# Altium schematic sheet Power.SchDoc(Power)
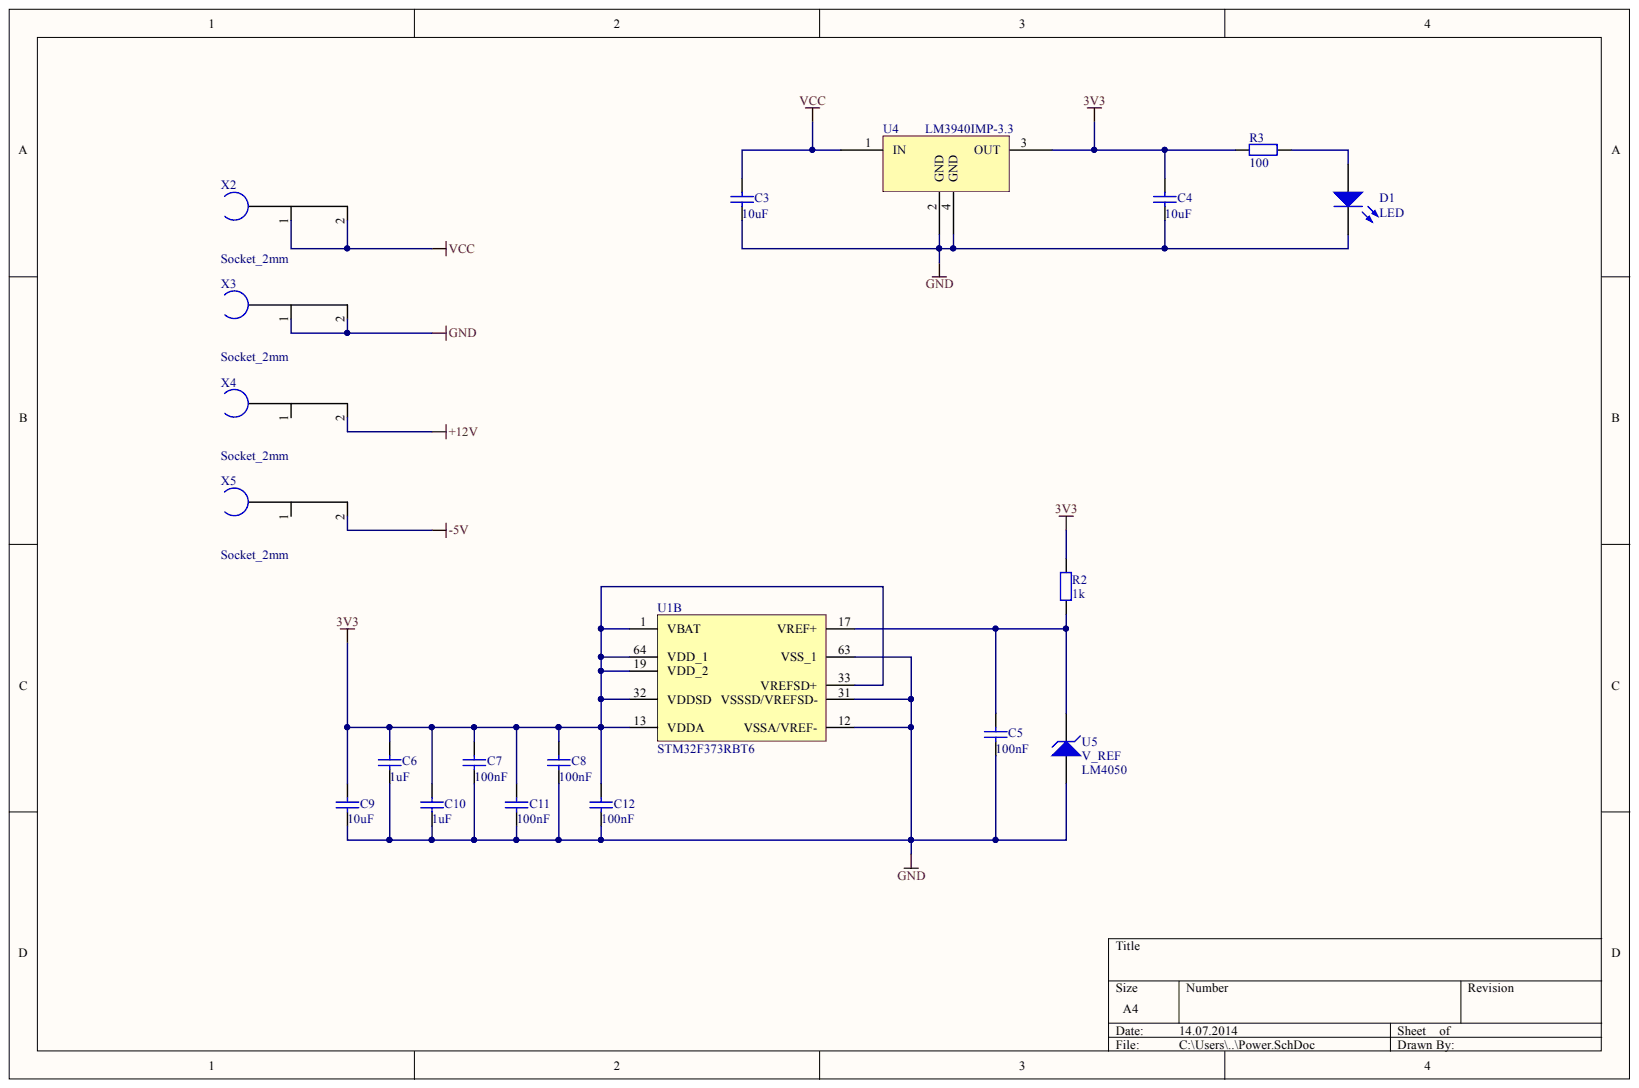
[diagram p0: Power.SchDoc]

## Parts
C3  C  value 10uF  [0805]
C4  C  value 10uF  [0805]
C5  C  value 100nF  [0805]
C6  C  value 1uF  [0805]
C7  C  value 100nF  [0805]
C8  C  value 100nF  [0805]
C9  C  value 10uF  [0805]
C10  C  value 1uF  [0805]
C11  C  value 100nF  [0805]
C12  C  value 100nF  [0805]
D1  LED  [D_0603]
R2  R  value 1k  [R_0603]
R3  R  value 100  [R_0603]
U1  STM32F373RBT6  [STM-LQFP64_V]  (part B)
U4  LM3940IMP-3.3  [SOT-223]
U5  V_REF  value LM4050  [SOT-23]
X2  Socket_2mm  [2MM_BANANA]
X3  Socket_2mm  [2MM_BANANA]
X4  Socket_2mm  [2MM_BANANA]
X5  Socket_2mm  [2MM_BANANA]

## Nets
3V3: C4.2 C6.2 C7.2 C8.1 C9.2 C10.2 C11.2 C12.2 R2.2 R3.1 U1.1(VBAT) U1.13(VDDA) U1.19(VDD_2) U1.32(VDDSD) U1.33(VREFSD+) U1.64(VDD_1) U4.3(OUT)
+12V: X4.2
-5V: X5.2
GND: C3.1 C4.1 C5.1 C6.1 C7.1 C8.2 C9.1 C10.1 C11.1 C12.1 D1.2 U1.12(VSSA/VREF-) U1.31(VSSSD/VREFSD-) U1.63(VSS_1) U4.2(GND) U4.4(GND) U5.2 X3.1 X3.2
VCC: C3.2 U4.1(IN) X2.1 X2.2
NetC5_2: C5.2 R2.1 U1.17(VREF+) U5.1
NetD1_1: D1.1 R3.2

Not connected: X4 1; X5 1

## Library data of the parts
R2 R3 = RES_EU: Component Kind: Standard; Library Name: Schlib1.SchLib
U1 = CMP-0572-00032-2: ARM Cortex-M4 32-bit MCU, 128 KB Flash, 24 KB Internal RAM, 52 I/Os, 64-pin LQFP, -40 to 85 degC, Tray; FLASH Size (Prog) (kB): 128; I/Os (High Current): 52; Internal RAM Size (kB): 24; Operating Frequency(F) (Processor speed) (MHz): 72; Supply Voltage(Vcc) min (V): 2; Component Kind: Standard; ComponentLink1Description: Manufacturer URL; ComponentLink1URL: http://www.st.com/web/en/home.html; ComponentLink2Description: Datasheet; ComponentLink2URL: http://www.st.com/st-web-ui/static/active/en/resource/technical/document/datasheet/DM00046749.pdf; ComponentLink3Description: Package Specification; ComponentLink3URL: http://www.st.com/st-web-ui/static/active/en/resource/technical/document/datasheet/DM00037051.pdf; Core: ARM Cortex-M4; DatasheetVersion: Rev. 3; Library Name: Altium Content Vault; Mounting Technology: Surface Mount; PackageDescription: 64-Pin Low Profile Quad Flat Package, 10 x 10 mm Body, 0.5 mm Pitch; PackageReference: LQFP64; PackageVersion: Rev. 4, 06/2013; Packing: Tray; PartNumber: STM32F373RBT6
C3-C12 = C: Component Kind: Standard; Library Name: Schlib1.SchLib
D1 = LED: Component Kind: Standard; Library Name: Schlib1.SchLib
U4 = LM3940IMP-3.3: Component Kind: Standard; Library Name: Schlib1.SchLib
U5 = V_REF: Component Kind: Standard; Library Name: Schlib1.SchLib
X2-X5 = Socket_2mm: Component Kind: Standard; Library Name: Schlib1.SchLib
